# Altium schematic sheet JacdacEc30RgbLedRing8 105.SchDoc
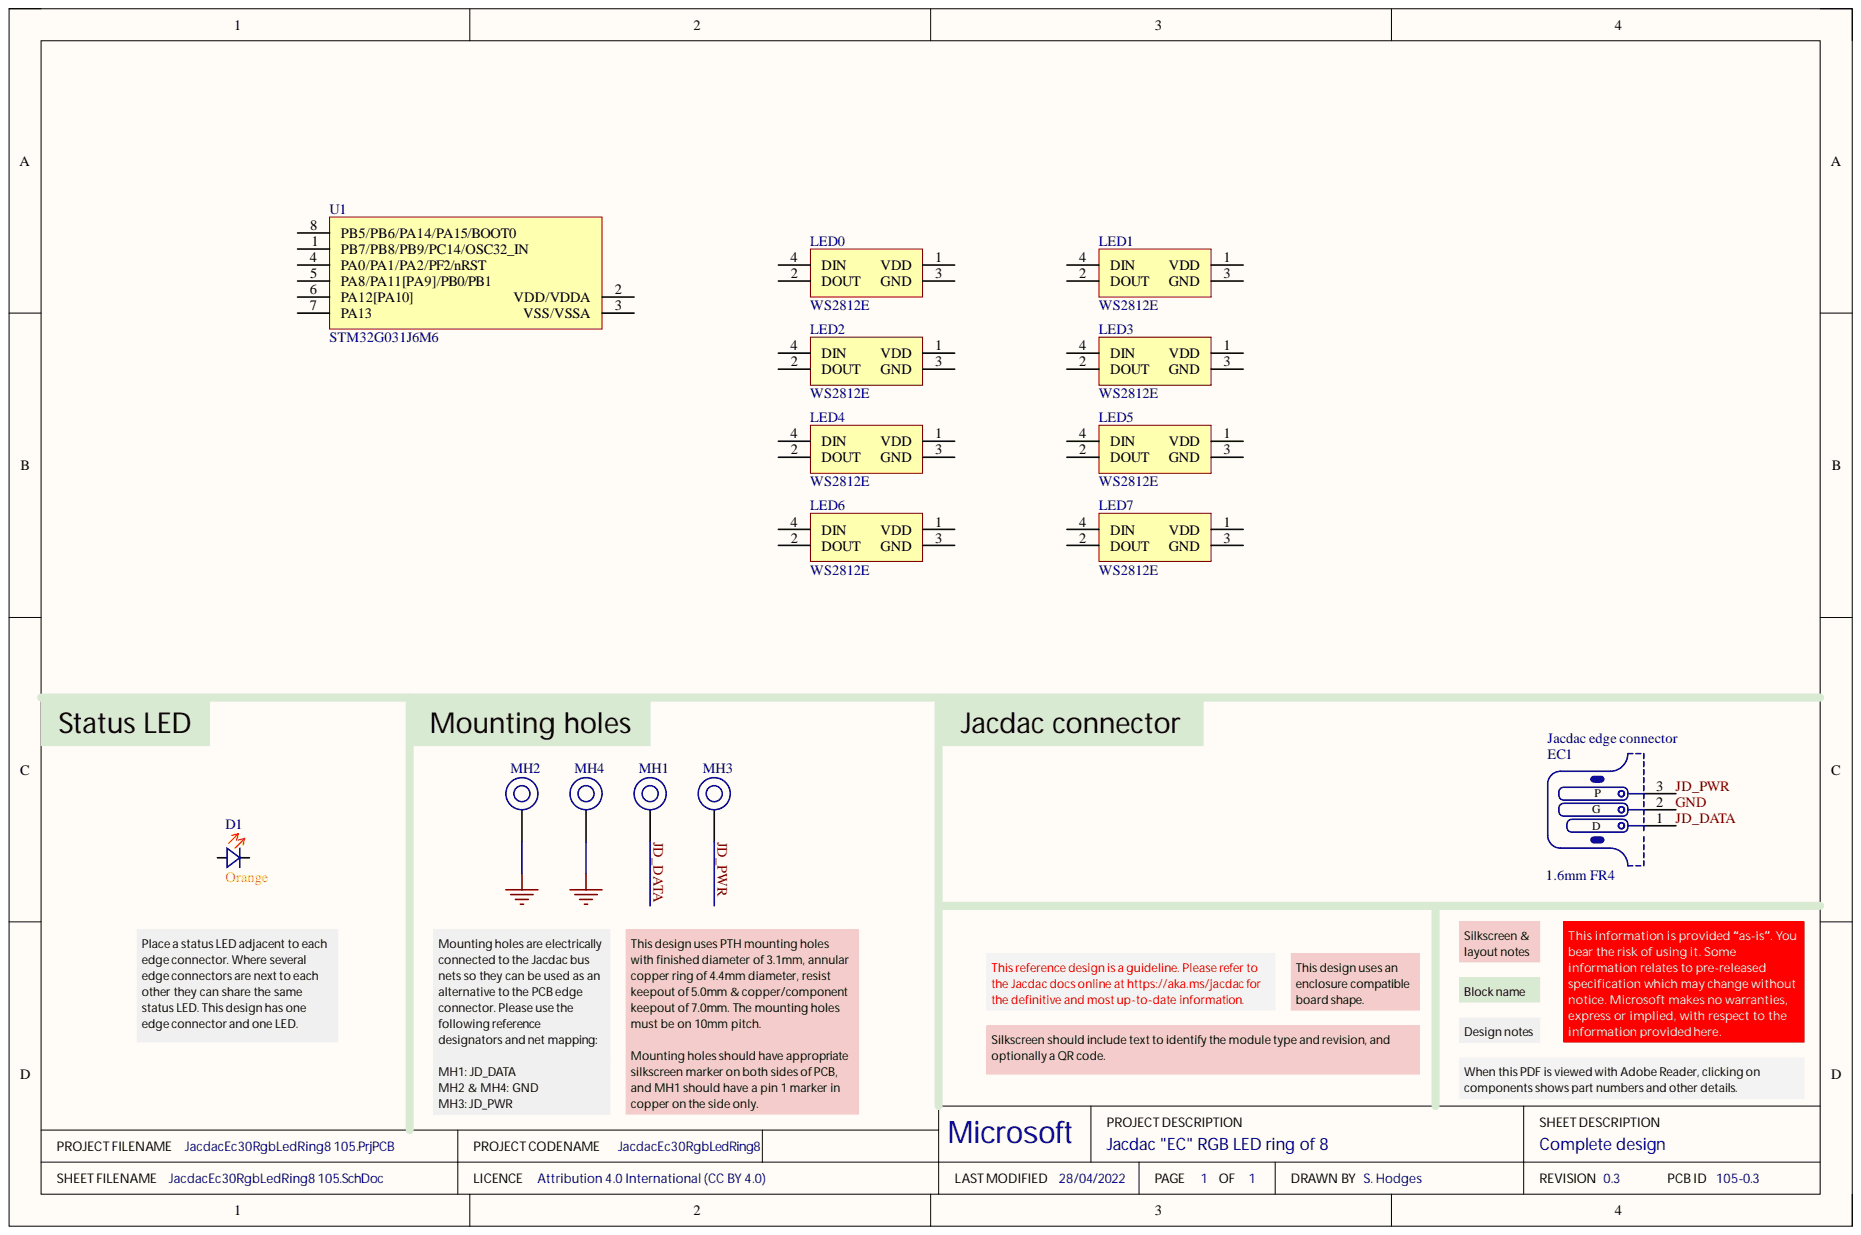
[diagram p0: JacdacEc30RgbLedRing8 105.SchDoc]

## Parts
D1  Color: Orange
EC1  PCB Type: 1.6mm FR4
LED0  Manufacturer Part Number: WS2812E
LED1  Manufacturer Part Number: WS2812E
LED2  Manufacturer Part Number: WS2812E
LED3  Manufacturer Part Number: WS2812E
LED4  Manufacturer Part Number: WS2812E
LED5  Manufacturer Part Number: WS2812E
LED6  Manufacturer Part Number: WS2812E
LED7  Manufacturer Part Number: WS2812E
MH1  (no value)
MH2  (no value)
MH3  (no value)
MH4  (no value)
U1  Manufacturer Part Number: STM32G031J6M6

## Nets
GND: EC1.2 MH2.1 MH4.1
JD_DATA: EC1.1 MH1.1
JD_PWR: EC1.3 MH3.1

Not connected: D1 1 2; LED0 1(VDD) 2(DOUT) 3(GND) 4(DIN); LED1 1(VDD) 2(DOUT) 3(GND) 4(DIN); LED2 1(VDD) 2(DOUT) 3(GND) 4(DIN); LED3 1(VDD) 2(DOUT) 3(GND) 4(DIN); LED4 1(VDD) 2(DOUT) 3(GND) 4(DIN); LED5 1(VDD) 2(DOUT) 3(GND) 4(DIN); LED6 1(VDD) 2(DOUT) 3(GND) 4(DIN); LED7 1(VDD) 2(DOUT) 3(GND) 4(DIN); U1 1(PB7/PB8/PB9/PC14/OSC32_IN) 2(VDD/VDDA) 3(VSS/VSSA) 4(PA0/PA1/PA2/PF2/nRST) 5(PA8/PA11[PA9]/PB0/PB1) 6(PA12[PA10]) 7(PA13) 8(PB5/PB6/PA14/PA15/BOOT0)

## Library data of the parts
D1 = (no symbol name): LED ORANGE DIFFUSED 0603 SMD; Manufacturer: Everlight Elec; Manufacturer Part Number: 19-217/S2C-AQ1R1B/3T; Case Code (Imperial): 0603; Case/Package: 0603; Colour: Orange; ComponentLink1Description: Online datasheet; ComponentLink1URL: https://datasheet.lcsc.com/lcsc/1912171731_Everlight-Elec-19-217-S2C-AQ1R1B-3T_C146215.pdf; Supplier 1: LCSC; Supplier 1 Part Number: C146215
EC1 = (no symbol name): 3 pin Jacdac edge connector 1.27mm pitch; Manufacturer Part Number: JACDAC_EDGE_CONNECTOR; ComponentLink1Description: Yuliang JACDAC cable connector drawing online; ComponentLink1URL: https://github.com/microsoft/jacdac-mdk/blob/main/connector/JACDAC-Connector-by-Yuliang-2020-11-28.pdf; ComponentLink2Description: JACDAC PCB edge connector spec onlin; ComponentLink2URL: https://github.com/microsoft/jacdac-mdk/blob/main/connector/JACDAC_PCB_Edge_Connector_Drawing_JD-PEC-01_rev_01.pdf
LED0-LED7 = (no symbol name): WS2812E 5050 RGB LED WITH INTEGRATED DRIVER; Manufacturer: Worldsemi; Color: Blue,Green,Red; Category: LEDs; ComponentLink1Description: Online datasheet; ComponentLink1URL: https://datasheet.lcsc.com/lcsc/1811151230_Worldsemi-WS2812E_C139127.pdf; Supplier 1: LCSC; Supplier 1 Part Number 3: C139127
MH1-MH4 = (no symbol name): Mounting Hole 6.5mm keepout, 4.5mm annualar ring and 3.0mm Drill PTH
U1 = (no symbol name): MCU 32-bit ARM Cortex M0+ RISC 32KB Flash 2.5V/3.3V 8-Pin SO N Tube; Manufacturer: STMicroelectronics; Category: Microcontrollers; ComponentLink1Description: Online datasheet; ComponentLink1URL: https://www.st.com/resource/en/datasheet/stm32g031c6.pdf; Supplier 1: Digi-Key; Supplier 2: Future Electronics; Supplier 3: Mouser; Supplier Part Number 1: 497-19552-ND; Supplier Part Number 2: 2116576; Supplier Part Number 3: 511-STM32G031J6M6
